# Altium schematic sheet Sheet3.SchDoc
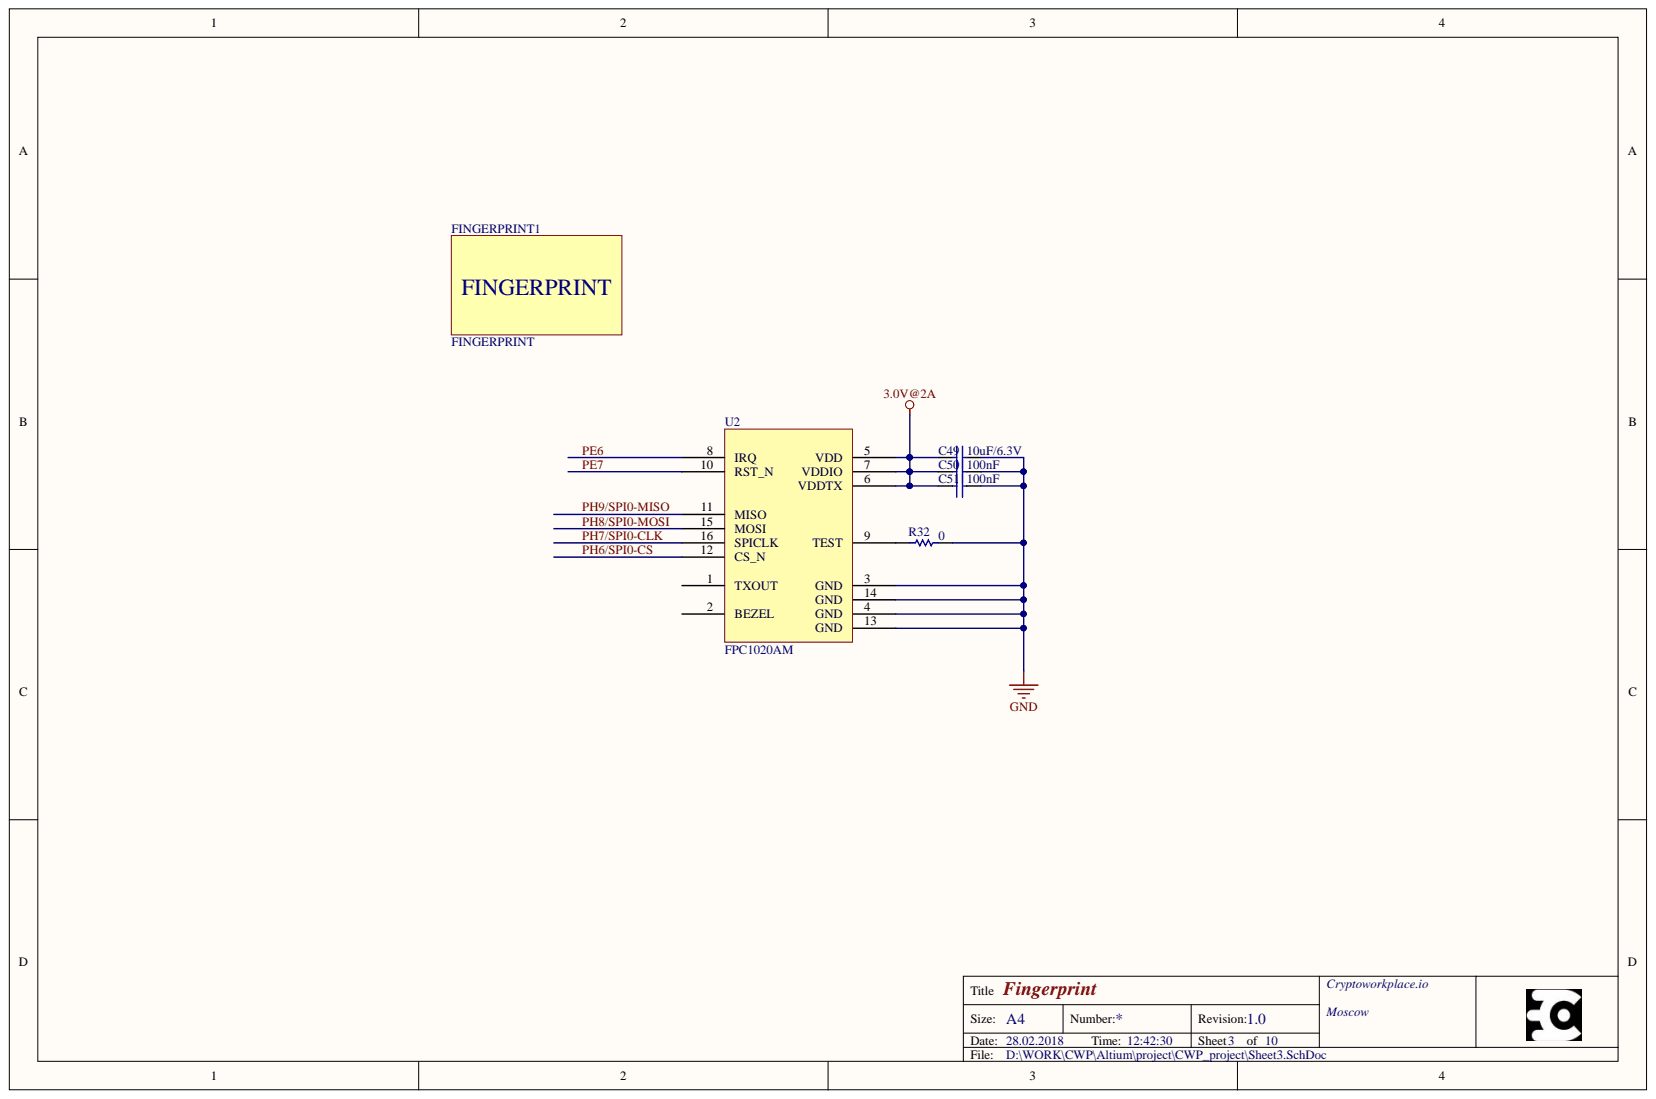
[diagram p0: Sheet3.SchDoc]

## Parts
C49  value 10uF/6.3V  [0603(CAPC1608X09N)]  (hidden comment: Cap)
C50  value 100nF  [0402(CAPC1005X06N)]  (hidden comment: 0402)
C51  value 100nF  [0402(CAPC1005X06N)]  (hidden comment: 0402)
FINGERPRINT1  FINGERPRINT  [FINGERPRINT]
R32  value 0  [0402(RESC1005X04N)]  (hidden comment: Res1)
U2  FPC1020AM  [BM10NB(0.8)-16DS-0.4V(51)]

## Nets
3.0V@2A: C49.1 C50.2 C51.2 U2.5(VDD) U2.6(VDDTX) U2.7(VDDIO)
GND: C49.2 C50.1 C51.1 R32.1 U2.3(GND) U2.4(GND) U2.13(GND) U2.14(GND)
PE6: U2.8(IRQ)
PE7: U2.10(RST_N)
PH6/SPI0-CS: U2.12(CS_N)
PH7/SPI0-CLK: U2.16(SPICLK)
PH8/SPI0-MOSI: U2.15(MOSI)
PH9/SPI0-MISO: U2.11(MISO)
NetR32_2: R32.2 U2.9(TEST)

Not connected: U2 1(TXOUT) 2(BEZEL)

## Library data of the parts
C49-C51 = Cap: Capacitor; Component Kind: Standard; LatestRevisionDate: 17-Jul-2002; LatestRevisionNote: Re-released for DXP Platform.; Library Name: Miscellaneous Devices.IntLib; PackageDescription: Radial Cap, Thru-Hole; 2 Leads; 0.3 in Pin Spacing; PackageReference: RAD-0.3; Published: 8-Jun-2000; Signal Integrity: Cap; Simulation: CAP; Publisher: Altium Limited
R32 = Res1: Resistor; Component Kind: Standard; LatestRevisionDate: 17-Jul-2002; LatestRevisionNote: Re-released for DXP Platform.; Library Name: Miscellaneous Devices.IntLib; PackageDescription: Axial Device, Thru-Hole; 2 Leads; 0.3 in Pin Spacing; PackageReference: AXIAL-0.3; Published: 8-Jun-2000; Signal Integrity: Res; Simulation: RESISTOR; Publisher: Altium Limited
U2 = FPC1020AM: Area Sensor Module; Component Kind: Standard; Library Name: FINGERPRINTS.SchLib
FINGERPRINT1 = FINGERPRINT: Component Kind: Standard; Description: FINGERPRINT; Library Name: OLED.SchLib
